# Altium schematic sheet IoTextra Analog_ADC.SchDoc("IoTextra Analog_ADC")
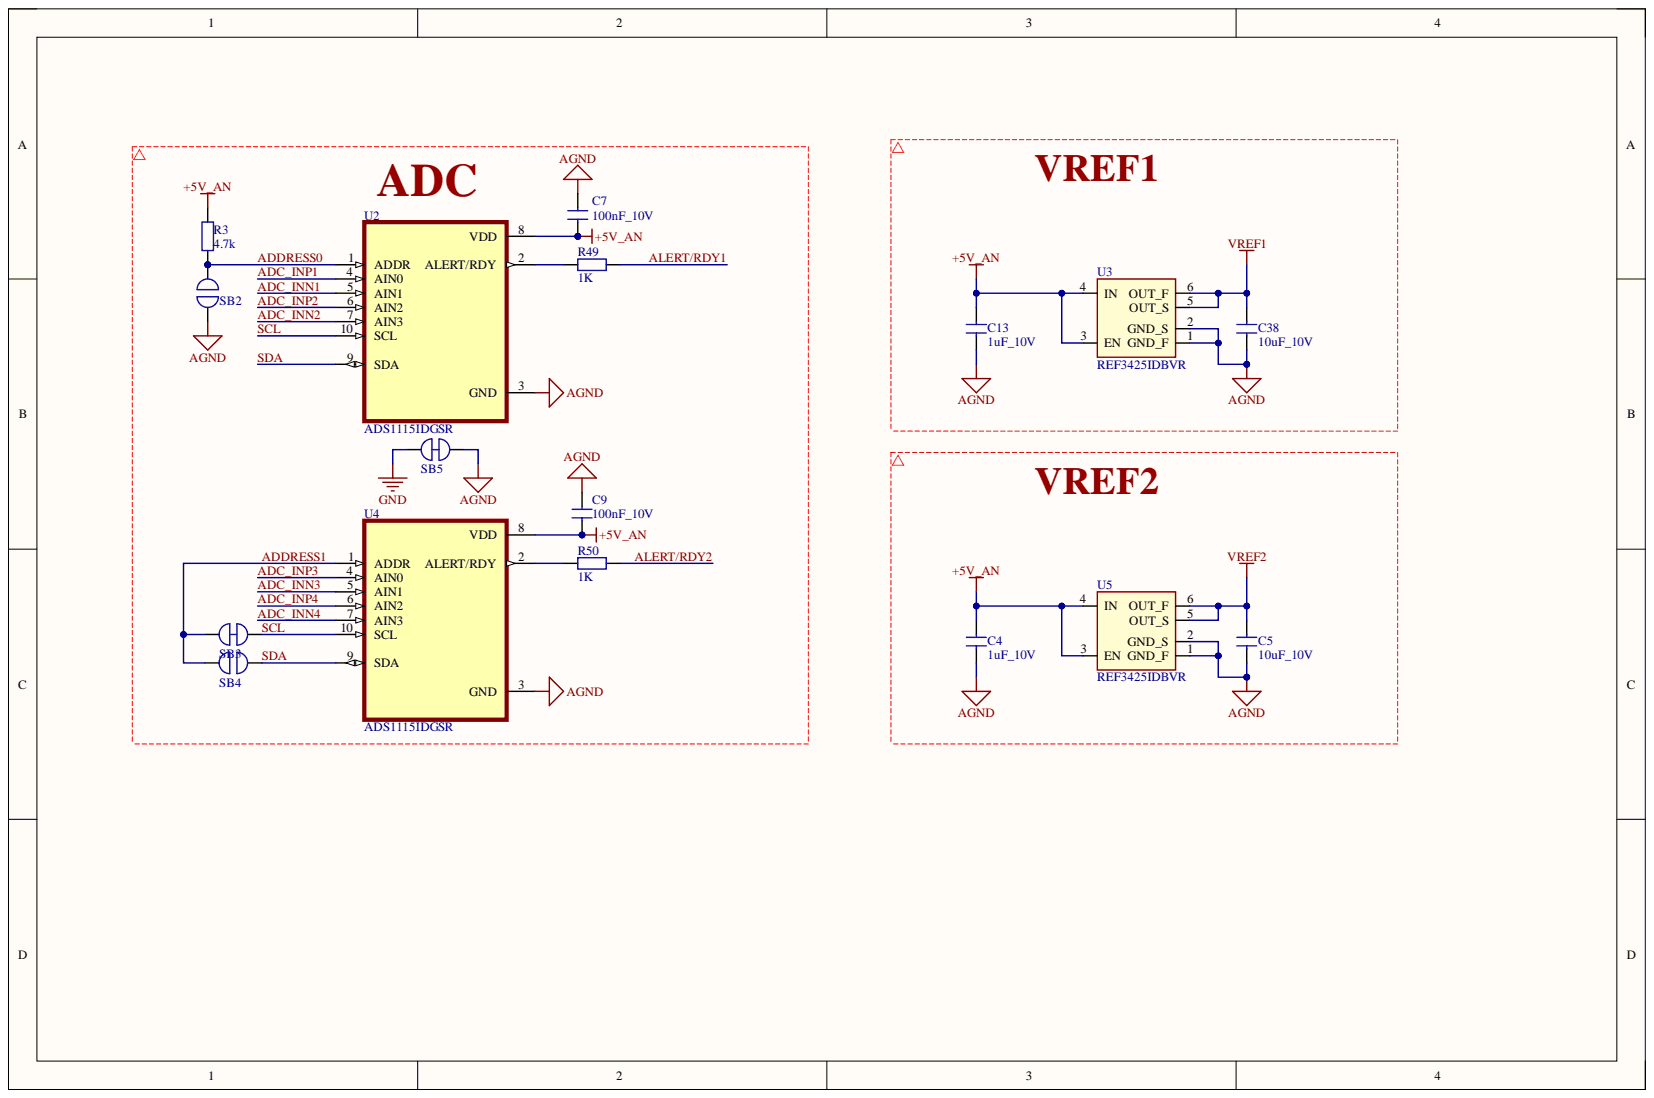
[diagram p0: IoTextra Analog_ADC.SchDoc]

## Parts
C4  1uF_10V  [C0603]
C5  10uF_10V  [CN-SMD-0402]
C7  100nF_10V  [CN-SMD-0402]
C9  100nF_10V  [CN-SMD-0402]
C13  1uF_10V  [C0603]
C38  10uF_10V  [CN-SMD-0402]
R3  4.7k  [RESC-0603 (1608) - HD]
R49  1K  [RESC-0603 (1608) - HD]
R50  1K  [RESC-0603 (1608) - HD]
SB2  SOLDER_JUMPER_NO  [SOLDER_JUMPER_NO]  (value not drawn)
SB3  SOLDER_JUMPER_NC  [SOLDER_JUMPER_NC]  (value not drawn)
SB4  SOLDER_JUMPER_NO  [SOLDER_JUMPER_NO]  (value not drawn)
SB5  SOLDER_JUMPER_NC  [SOLDER_JUMPER_NC]  (value not drawn)
U2  ADS1115IDGSR  [VSSOP-10]
U3  REF3425IDBVR  [SOT23-6]
U4  ADS1115IDGSR  [VSSOP-10]
U5  REF3425IDBVR  [SOT23-6]

## Nets
+5V_AN: C4.2 C7.1 C9.1 C13.2 R3.2 U2.8 U3.3 U3.4 U4.8 U5.3 U5.4
AGND: C4.1 C5.1 C7.2 C9.2 C13.1 C38.1 SB2.1 SB5.2 U2.3 U3.1 U3.2 U4.3 U5.1 U5.2
GND: SB5.1
VREF1: C38.2 U3.5 U3.6
VREF2: C5.2 U5.5 U5.6
ADC_INN1: U2.5
ADC_INN2: U2.7
ADC_INN3: U4.5
ADC_INN4: U4.7
ADC_INP1: U2.4
ADC_INP2: U2.6
ADC_INP3: U4.4
ADC_INP4: U4.6
ADDRESS0: R3.1 SB2.2 U2.1
ADDRESS1: SB3.1 SB4.1 U4.1
ALERT/RDY1: R49.2
ALERT/RDY2: R50.2
SCL: SB3.2 U2.10 U4.10
SDA: SB4.2 U2.9 U4.9
NetR49_1: R49.1 U2.2
NetR50_1: R50.1 U4.2

## Library data of the parts
C4 C13 = CL05A105KP5NNNC: 10V 1uF X5R ±10% 0603 Multilayer Ceramic Capacitors MLCC - SMD/SMT ROHS; Component Kind: Standard; LCSC #: C14445; Library Name: Bokra.SCHLIB
C5 C38 = CL05A106MP5NUNC: 10V 10uF X5R ±20% 0402 Multilayer Ceramic Capacitors MLCC - SMD/SMT ROHS; Component Kind: Standard; LCSC #: C315248; Library Name: Bokra.SCHLIB
C7 C9 = CL05B104KP5NNNC: 10V 100nF X7R ±10% 0402 Multilayer Ceramic Capacitors MLCC - SMD/SMT ROHS; Component Kind: Standard; LCSC #: C133086; Library Name: Bokra.SCHLIB
R3 = RC0603FR-074K7L: RES 4.7K OHM 1% 1/10W 0603; MFR: Yageo; Category: RESISTOR; Component Kind: Standard; LCSC #: C99782; MPN: RC0603FR-074K7L; Solder: RES-0603; URL: https://www.digikey.com/en/products/detail/yageo/RC0603FR-074K7L/727212; Value: 4.7k; Library Name: Bokra.SCHLIB
R49 R50 = RC0603FR-071KL: RES SMD 1 KOHM 1% 1/10W 0603; Solder: SMT; Component Kind: Standard; LCSC #: C22548; MPN: RC0603FR-071KL; Value: 1K; Library Name: Bokra.SCHLIB
SB2 SB4 = SOLDER_JUMPER_NO: Solder Jumper; Component Kind: Net Tie; Library Name: Bokra.SCHLIB
SB3 SB5 = SOLDER_JUMPER_NC: Solder Jumper; Component Kind: Net Tie; Library Name: Bokra.SCHLIB
U2 U4 = ADS1115IDGSR: -40℃~+125℃ 0.5uA 16 16Hz 1LSB 2 2.048V 2V~5.5V Built-In Built-In I2C Sigma-Delta Support Yes VSSOP-10 Analog to Digital Converters (ADC) ROHS; Component Kind: Standard; LCSC #: C37593; Value: ADS1115IDGSR; Library Name: Bokra.SCHLIB
U3 U5 = REF3425IDBVR: Low Temperature Drift, Low Power Consumption, Small-Size Series Voltage Reference; Component Kind: Standard; LCSC #: C187836; Value: REF3425IDBVR; Library Name: Bokra.SCHLIB
